# Altium schematic sheet 1-1 DCDC24V电源.SchDoc("1-1 DCDC24V电源")
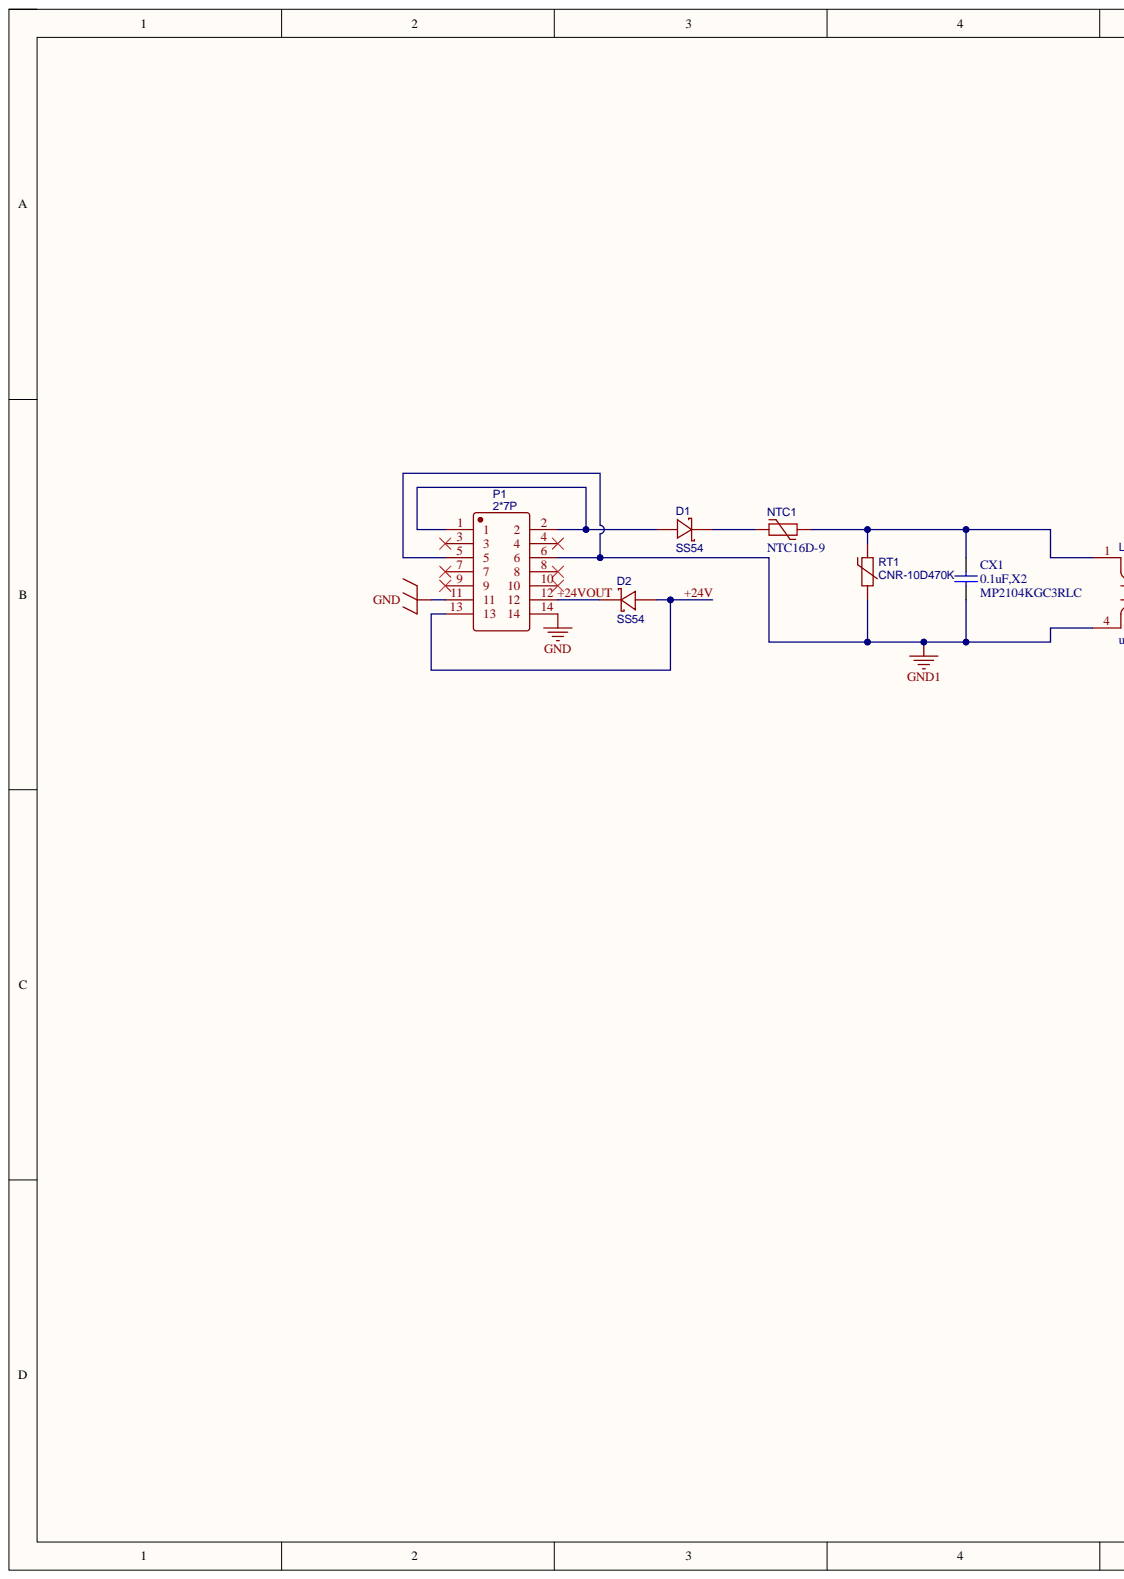
[diagram p0: 1-1 DCDC24V电源.SchDoc, left]
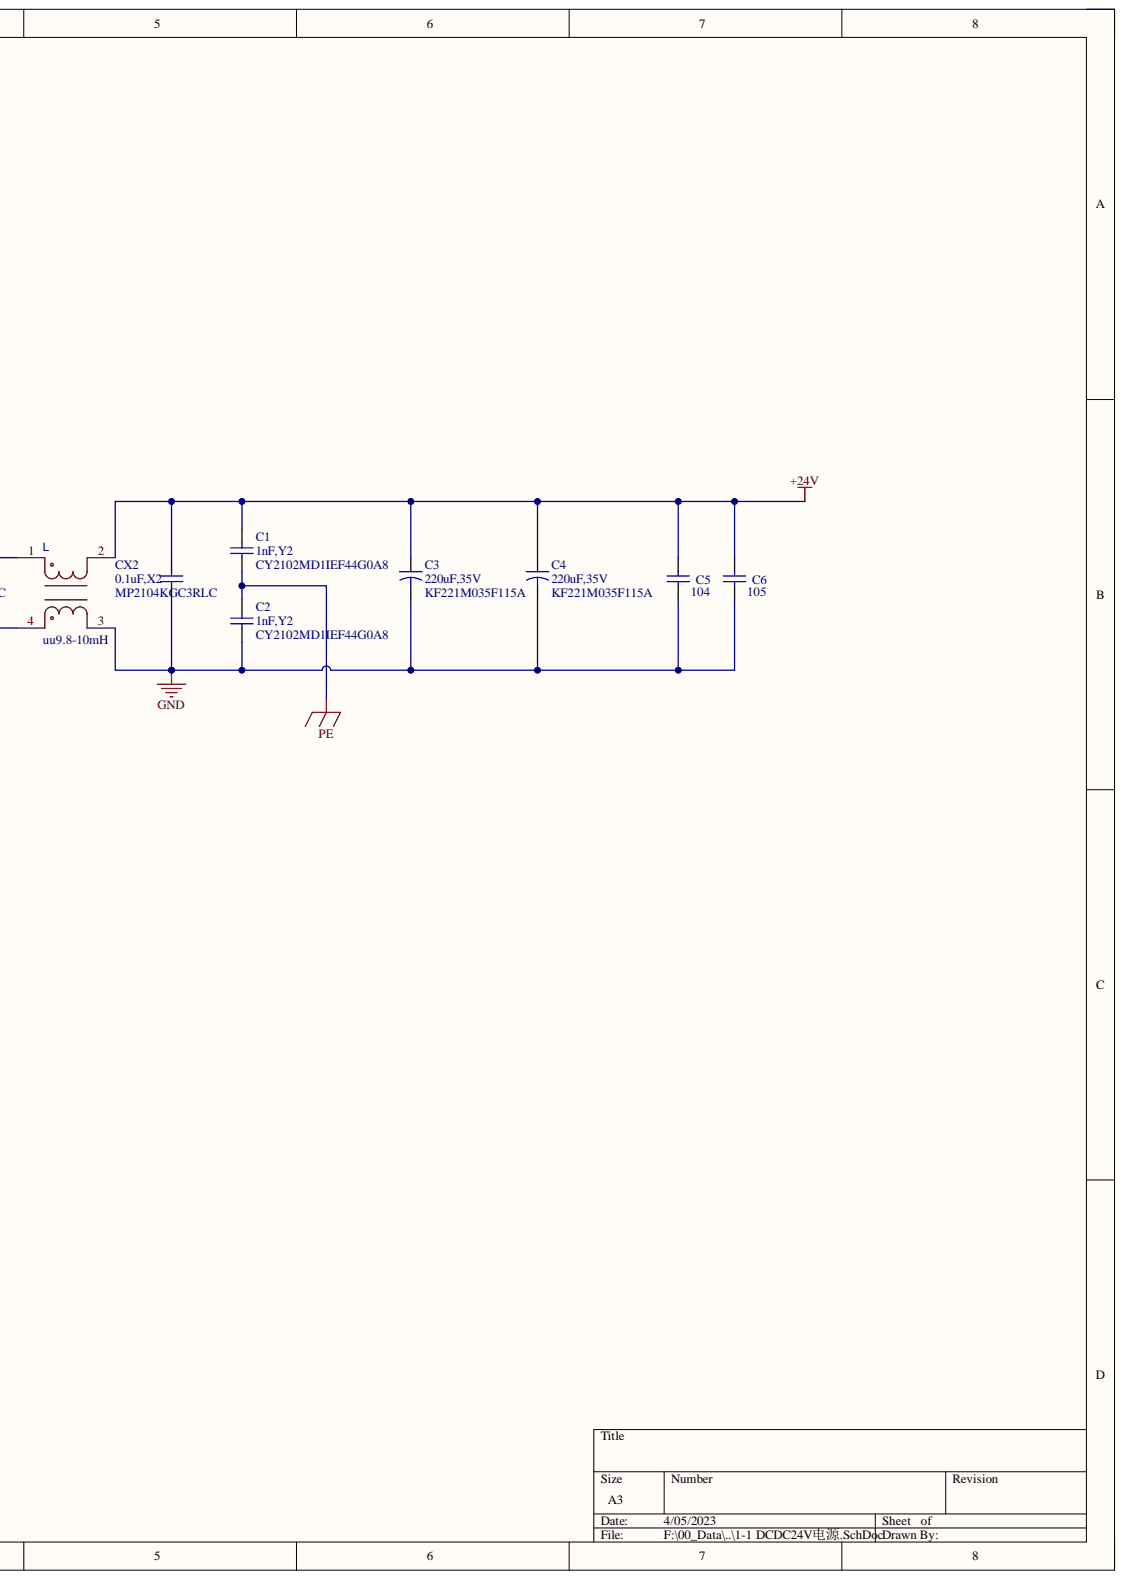
[diagram p1: 1-1 DCDC24V电源.SchDoc, right]

## Parts
C1  1nF,Y2  value CY2102MD1IEF44G0A8  [CAP-SAFE-8.5*5.0-P7.5]
C2  1nF,Y2  value CY2102MD1IEF44G0A8  [CAP-SAFE-8.5*5.0-P7.5]
C3  220uF,35V  value KF221M035F115A  [CAP-D8.0XF3.5]
C4  220uF,35V  value KF221M035F115A  [CAP-D8.0XF3.5]
C5  104  [0603_C]
C6  105  [0603_C]
CX1  0.1uF,X2  value MP2104KGC3RLC  [13*6*12/P=10]
CX2  0.1uF,X2  value MP2104KGC3RLC  [13*6*12/P=10]
D1  SS54  [SMB(DO-214AA)]
D2  SS54  [SMB(DO-214AA)]
L  value uu9.8-10mH  spicePre: L  [DIP-12X6.5X16.5]  (hidden comment: TDK)
NTC1  value NTC16D-9  [VDR-7.5-11X6]  (hidden comment: SOCAY)
P1  2*7P  [HDR-TH_14P-P2.54-V-F-R2-C7-S2.54-1]
RT1  CNR-10D470K  [RES-TH_L12.5-W5.5-P7.50-D0.8-S5.50]

## Nets
GND: C2.1 C3.2 C4.2 C5.1 C6.1 CX2.1 L.3 P1.11 P1.14
GND1: CX1.1 L.4 P1.5 P1.6 RT1.2
PE: C1.1 C2.2
+24V: C1.2 C3.1 C4.1 C5.2 C6.2 CX2.2 D2.1 L.2 P1.13
+24VOUT: D2.2 P1.12
NetCX1_2: CX1.2 L.1 NTC1.1 RT1.1
NetD1_1: D1.1 P1.1 P1.2
NetD1_2: D1.2 NTC1.2

Not connected: P1 3 4 7 8 9 10

## Library data of the parts
C1 C2 CX1 CX2 = Cap: Capacitor; Component Kind: Standard; LatestRevisionDate: 17-Jul-2002; LatestRevisionNote: Re-released for DXP Platform.; Library Name: Miscellaneous Devices.IntLib; PackageDescription: Radial Cap, Thru-Hole; 2 Leads; 0.3 in Pin Spacing; PackageReference: RAD-0.3; Published: 8-Jun-2000; Publisher: Altium Limited
C3 C4 = Cap2: Capacitor; Component Kind: Standard; Library Name: Miscellaneous Devices.IntLib; PackageDescription: Capacitor; 2 Leads; PackageReference: CAPR5-4X5; Published: 23-Sep-2002; Publisher: Altium Limited
C5 C6 = Cap_0603: 0603贴片电容; Component Kind: Standard; Library Name: 02-Miscellaneous_Devices_ZSF_Library.IntLib; Package: 0805; 常见贴片电容尺寸图: https://wenku.baidu.com/view/21d5206100f69e3143323968011ca300a6c3f6b2.html
  C5: Value: 104
  C6: Value: 105
D1 D2 = P6KE200A: BOM_Manufacturer: GOOD-ARK; Contributor: LCSC; BOM_Manufacturer Part: P6KE200A; BOM_Supplier: LCSC; BOM_Supplier Part: C174012; Component Kind: Standard; spicePre: D
L = TDK: BOM_Manufacturer Part: ACT45L-201-2P-TL000; Contributor: LCSC; nameAlias: Value; BOM_Manufacturer: TDK; BOM_Supplier: LCSC; BOM_Supplier Part: C131445; Component Kind: Standard; spiceSymbolName: ACT45L-201-2P-TL000; Library Name: XG_LIB.SchLib
NTC1 = SOCAY: BOM_Manufacturer: SOCAY Elec; BOM_Manufacturer Part: SCN10D-5; Contributor: LCSC; nameAlias: Value; BOM_Supplier: LCSC; BOM_Supplier Part: C305622; Component Kind: Standard; spicePre: R; spiceSymbolName: SCN10D-5
P1 = 220S-2*7P H=8.5MM Ytype Gold-plated: BOM_Manufacturer: Ckmtw; Contributor: LCSC; BOM_JLCPCB Part Class: Extended Part; BOM_Manufacturer Part: 220S-2*7P H=8.5MM Ytype Gold-plated; BOM_Supplier: LCSC; BOM_Supplier Part: C124424; Component Kind: Standard; spicePre: H; spiceSymbolName: 220S-2*7P H=8.5MM Ytype Gold-plated
RT1 = CNR-10D561K: BOM_Manufacturer: CNR; Contributor: LCSC; BOM_JLCPCB Part Class: Extended Part; BOM_Manufacturer Part: CNR-10D561K; BOM_Supplier: LCSC; BOM_Supplier Part: C524034; Component Kind: Standard; spicePre: R; spiceSymbolName: CNR-10D561K
